# Altium schematic sheet S7CAS-PLEIADES-CARTE-MERE_P01_CAN.SchDoc
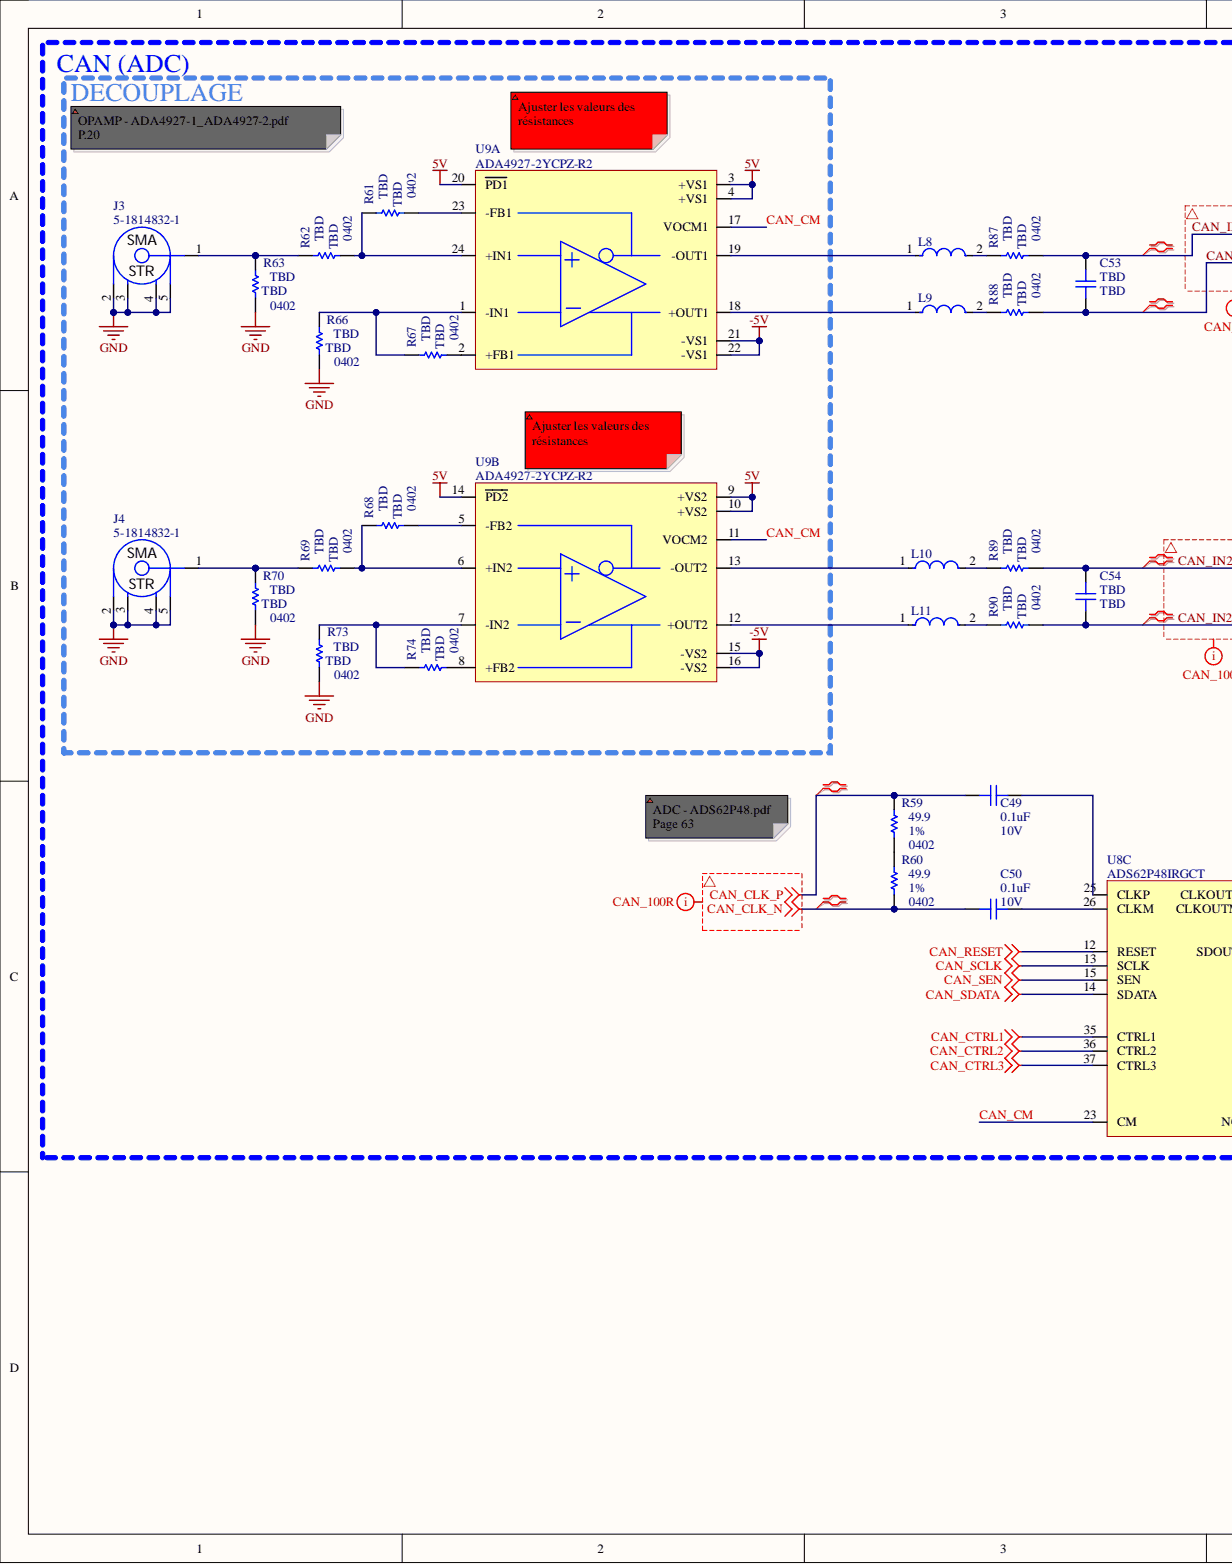
[diagram p0: S7CAS-PLEIADES-CARTE-MERE_P01_CAN.SchDoc, left]
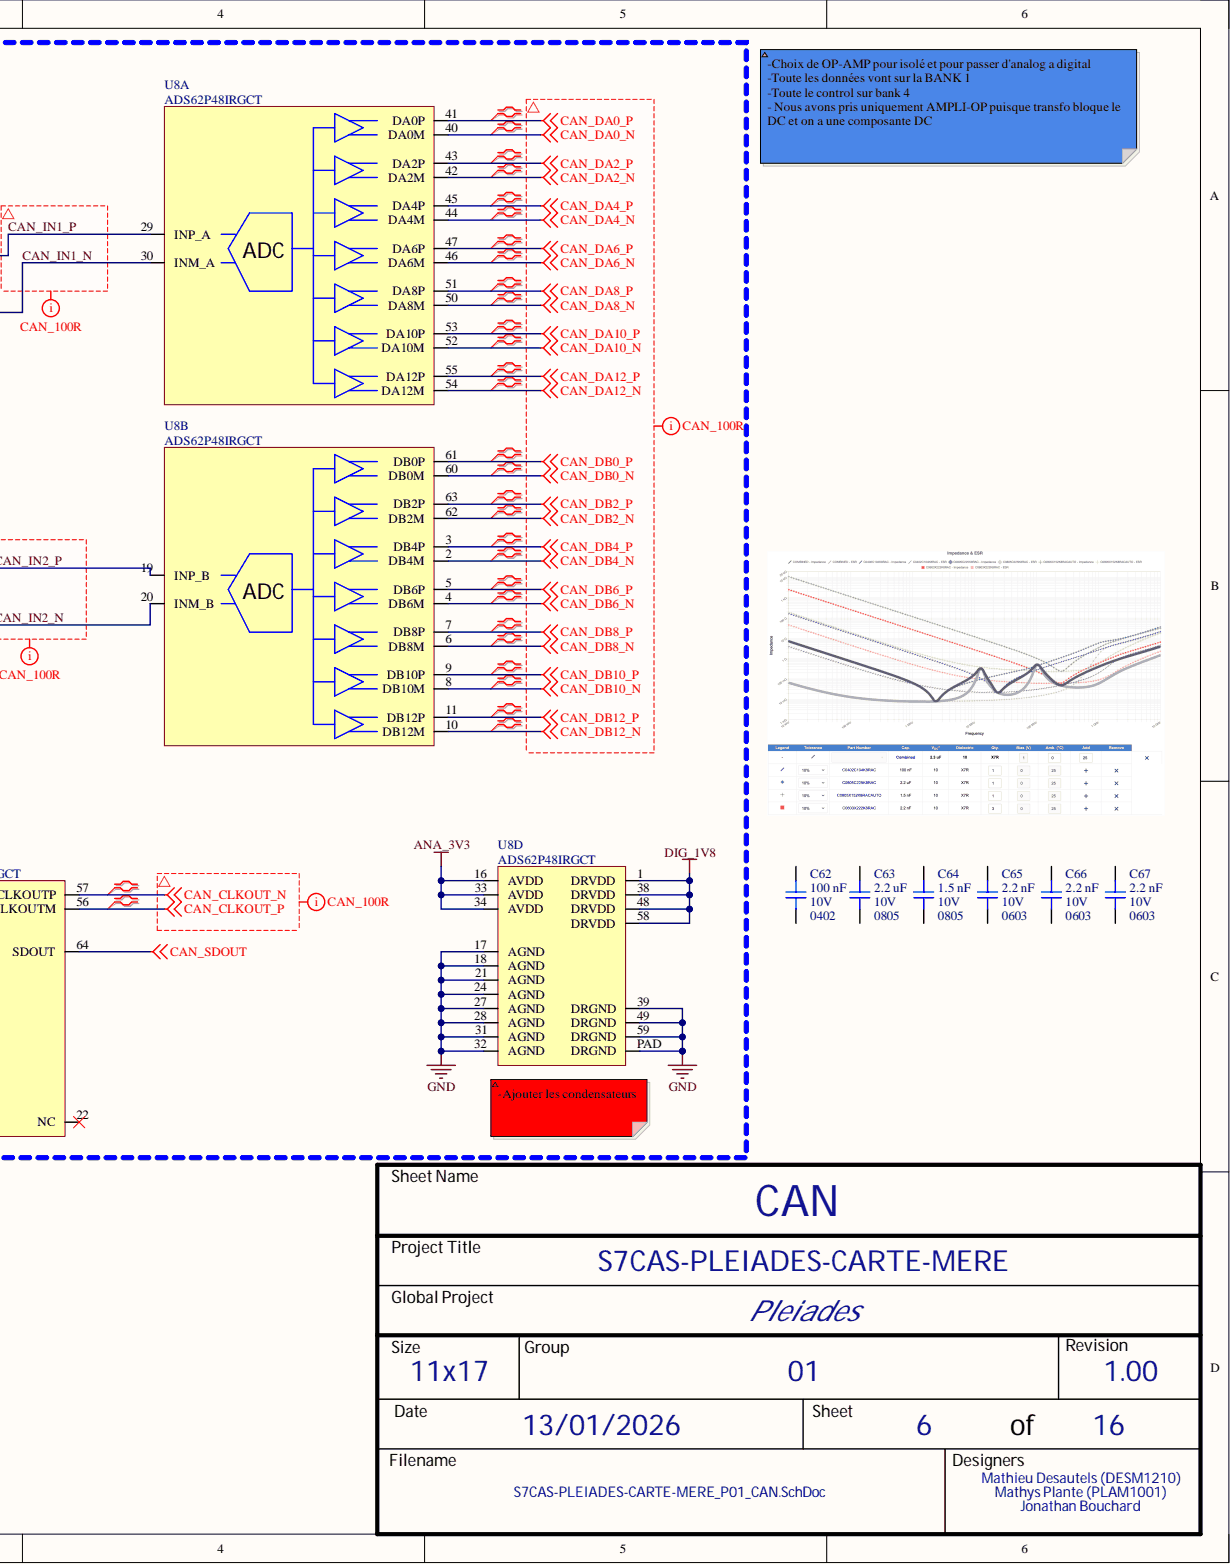
[diagram p1: S7CAS-PLEIADES-CARTE-MERE_P01_CAN.SchDoc, right]

## Parts
C49  value 0.1uF  Voltage: 10V  [CAP_0402]  (hidden comment: CAP - 0402)
C50  value 0.1uF  Voltage: 10V  [CAP_0402]  (hidden comment: CAP - 0402)
C53  value TBD  Mfg: TBD  [CAP_0402]  (hidden comment: CAP - 0402)
C54  value TBD  Mfg: TBD  [CAP_0402]  (hidden comment: CAP - 0402)
C62  value 100 nF  Package: 0402  Voltage: 10V  [CAP_0402]  (hidden comment: CAP - 0402)
C63  value 2.2 uF  Package: 0805  Voltage: 10V  [CAP_0805]  (hidden comment: CAP - 0805)
C64  value 1.5 nF  Package: 0805  Voltage: 10V  [CAP_0805]  (hidden comment: CAP - 0805)
C65  value 2.2 nF  Package: 0603  Voltage: 10V  [CAP_0603]  (hidden comment: CAP - 0603)
C66  value 2.2 nF  Package: 0603  Voltage: 10V  [CAP_0603]  (hidden comment: CAP - 0603)
C67  value 2.2 nF  Package: 0603  Voltage: 10V  [CAP_0603]  (hidden comment: CAP - 0603)
J3  5-1814832-1  [CONN_SMA_5-1814832-1]
J4  5-1814832-1  [CONN_SMA_5-1814832-1]
L8  LQH5BPN1R2NT0L  [FP-LQH5BPB_T0-MFG]  (value not drawn)
L9  LQH5BPN1R2NT0L  [FP-LQH5BPB_T0-MFG]  (value not drawn)
L10  LQH5BPN1R2NT0L  [FP-LQH5BPB_T0-MFG]  (value not drawn)
L11  LQH5BPN1R2NT0L  [FP-LQH5BPB_T0-MFG]  (value not drawn)
R59  value 49.9  Precision: 1%  [RES_0402]  (hidden comment: RES - 0402)
R60  value 49.9  Package: 0402  Precision: 1%  [RES_0402]  (hidden comment: RES - 0402)
R61  value TBD  Mfg: TBD  Package: 0402  [RES_0402]  (hidden comment: RES - 0402)
R62  value TBD  Mfg: TBD  [RES_0402]  (hidden comment: RES - 0402)
R63  value TBD  Mfg: TBD  Package: 0402  [RES_0402]  (hidden comment: RES - 0402)
R66  value TBD  Mfg: TBD  Package: 0402  [RES_0402]  (hidden comment: RES - 0402)
R67  value TBD  Mfg: TBD  [RES_0402]  (hidden comment: RES - 0402)
R68  value TBD  Mfg: TBD  Package: 0402  [RES_0402]  (hidden comment: RES - 0402)
R69  value TBD  Mfg: TBD  [RES_0402]  (hidden comment: RES - 0402)
R70  value TBD  Mfg: TBD  Package: 0402  [RES_0402]  (hidden comment: RES - 0402)
R73  value TBD  Mfg: TBD  Package: 0402  [RES_0402]  (hidden comment: RES - 0402)
R74  value TBD  Mfg: TBD  [RES_0402]  (hidden comment: RES - 0402)
R87  value TBD  Mfg: TBD  Package: 0402  [RES_0402]  (hidden comment: RES - 0402)
R88  value TBD  Mfg: TBD  Package: 0402  [RES_0402]  (hidden comment: RES - 0402)
R89  value TBD  Mfg: TBD  Package: 0402  [RES_0402]  (hidden comment: RES - 0402)
R90  value TBD  Mfg: TBD  Package: 0402  [RES_0402]  (hidden comment: RES - 0402)
U8  ADS62P48IRGCT  [ADC_ADS62P48IRGCT]  (parts A,B,C,D)
U9  ADA4927-2YCPZ-R2  [OPAMP_ADA4927-2YCPZ-R2]  (parts A,B)

## Nets
5V: U9.3(+VS1) U9.4(+VS1) U9.9(+VS2) U9.10(+VS2) U9.14(PD2) U9.20(PD1)
-5V: U9.15(-VS2) U9.16(-VS2) U9.21(-VS1) U9.22(-VS1)
ANA_3V3: U8.16(AVDD) U8.33(AVDD) U8.34(AVDD)
CAN_CLK_N: C50.2 R60.1
CAN_CLK_P: C49.2 R59.2
CAN_CLKOUT_N: U8.57(CLKOUTP)
CAN_CLKOUT_P: U8.56(CLKOUTM)
CAN_CTRL1: U8.35(CTRL1)
CAN_CTRL2: U8.36(CTRL2)
CAN_CTRL3: U8.37(CTRL3)
CAN_DA0_N: U8.40(DA0M)
CAN_DA0_P: U8.41(DA0P)
CAN_DA2_N: U8.42(DA2M)
CAN_DA2_P: U8.43(DA2P)
CAN_DA4_N: U8.44(DA4M)
CAN_DA4_P: U8.45(DA4P)
CAN_DA6_N: U8.46(DA6M)
CAN_DA6_P: U8.47(DA6P)
CAN_DA8_N: U8.50(DA8M)
CAN_DA8_P: U8.51(DA8P)
CAN_DA10_N: U8.52(DA10M)
CAN_DA10_P: U8.53(DA10P)
CAN_DA12_N: U8.54(DA12M)
CAN_DA12_P: U8.55(DA12P)
CAN_DB0_N: U8.60(DB0M)
CAN_DB0_P: U8.61(DB0P)
CAN_DB2_N: U8.62(DB2M)
CAN_DB2_P: U8.63(DB2P)
CAN_DB4_N: U8.2(DB4M)
CAN_DB4_P: U8.3(DB4P)
CAN_DB6_N: U8.4(DB6M)
CAN_DB6_P: U8.5(DB6P)
CAN_DB8_N: U8.6(DB8M)
CAN_DB8_P: U8.7(DB8P)
CAN_DB10_N: U8.8(DB10M)
CAN_DB10_P: U8.9(DB10P)
CAN_DB12_N: U8.10(DB12M)
CAN_DB12_P: U8.11(DB12P)
CAN_RESET: U8.12(RESET)
CAN_SCLK: U8.13(SCLK)
CAN_SDATA: U8.14(SDATA)
CAN_SDOUT: U8.64(SDOUT)
CAN_SEN: U8.15(SEN)
DIG_1V8: U8.1(DRVDD) U8.38(DRVDD) U8.48(DRVDD) U8.58(DRVDD)
GND: J3.2 J3.3 J3.4 J3.5 J4.2 J4.3 J4.4 J4.5 R63.1 R66.1 R70.1 R73.1 U8.17(AGND) U8.18(AGND) U8.21(AGND) U8.24(AGND) U8.27(AGND) U8.28(AGND) U8.31(AGND) U8.32(AGND) U8.39(DRGND) U8.49(DRGND) U8.59(DRGND) U8.PAD(DRGND)
CAN_CM: U8.23(CM) U9.11(VOCM2) U9.17(VOCM1)
CAN_IN1_N: C53.1 R88.1 U8.30(INM_A)
CAN_IN1_P: C53.2 R87.1 U8.29(INP_A)
CAN_IN2_N: C54.1 R90.1 U8.20(INM_B)
CAN_IN2_P: C54.2 R89.1 U8.19(INP_B)
NetC49_1: C49.1 U8.25(CLKP)
NetC50_1: C50.1 U8.26(CLKM)
NetJ3_1: J3.1 R62.2 R63.2
NetJ4_1: J4.1 R69.2 R70.2
NetL8_1: L8.1 U9.19(-OUT1)
NetL8_2: L8.2 R87.2
NetL9_1: L9.1 U9.18(+OUT1)
NetL9_2: L9.2 R88.2
NetL10_1: L10.1 U9.13(-OUT2)
NetL10_2: L10.2 R89.2
NetL11_1: L11.1 U9.12(+OUT2)
NetL11_2: L11.2 R90.2
NetR59_1: R59.1 R60.2
NetR61_1: R61.1 U9.23(-FB1)
NetR61_2: R61.2 R62.1 U9.24(+IN1)
NetR66_2: R66.2 R67.2 U9.1(-IN1)
NetR67_1: R67.1 U9.2(+FB1)
NetR68_1: R68.1 U9.5(-FB2)
NetR68_2: R68.2 R69.1 U9.6(+IN2)
NetR73_2: R73.2 R74.2 U9.7(-IN2)
NetR74_1: R74.1 U9.8(+FB2)

Not connected: C62 1 2; C63 1 2; C64 1 2; C65 1 2; C66 1 2; C67 1 2; U8 22(NC)

## Library data of the parts
C49 C50 = CAP - 0402: 0.1 µF ±10% 10V Ceramic Capacitor X5R 0402 (1005 Metric); Mfg Part Number: CL05A104KP5NNNC; Mfg: Samsung Electro-Mechanics; Component Kind: Standard; Library Name: s7cas_lib2023.intlib; Package: 0402; Part Number #1: CL05A104KP5NNNC; Precision: 10%; CreatedBy: Jonathan Bouchard
C53 C54 = CAP - 0402: TBD - 0402; Component Kind: Standard; Library Name: s7cas_lib2023.intlib; Mfg Part Number: TBD; Package: 0402; Part Number #1: TBD; Precision: TBD; Voltage: TBD; CreatedBy: Jonathan Bouchard
C62 = CAP - 0402: Mfg Part Number: C0402C104K8RAC; Precision: 10%; Component Kind: Standard; Description: CAP - 0402; Library Name: s7cas_lib2023.intlib; CreatedBy: Jonathan Bouchard
C63 = CAP - 0805: Mfg Part Number: C0805C225K8RAC; Precision: 10%; Component Kind: Standard; Description: CAP - 0805; Library Name: s7cas_lib2023.intlib; CreatedBy: Jonathan Bouchard
C64 = CAP - 0805: Mfg Part Number: C0805X152K8RACAUTO; Precision: 10%; Component Kind: Standard; Description: CAP - 0805; Library Name: s7cas_lib2023.intlib; CreatedBy: Jonathan Bouchard
C65-C67 = CAP - 0603: Mfg Part Number: C0603X222K8RAC; Precision: 10%; Component Kind: Standard; Description: CAP - 0603; Library Name: s7cas_lib2023.intlib; CreatedBy: Jonathan Bouchard
J3 J4 = CONN_SMA_5-1814832-1: CONN -  SMA JACK STR 50 OHM - TH; Mfg: TE Connectivity AMP Connectors; Component Kind: Standard; HelpURL: http://www.te.com/commerce/DocumentDelivery/DDEController?Action=srchrtrv&DocNm=1814832&DocType=Customer+Drawing&DocLang=English; Library Name: s7cas_lib2023.intlib; Mfg Part Number: 5-1814832-1; Package: TH-5; Supplier 1: Digikey; Supplier Part Number 1: A97594-ND; Temperature: -65°C ~ 165°C; CreatedBy: Jonathan Bouchard
L8-L11 = CMP-06042-001298-1: Wire Wound Ferrite Inductor for Power Lines 1.2μH ±30% 0.0228Ω 3.1A 2020; DC Resistance (DCR): 19mR; Inductance: 1.2uH; Saturation Current: 5.4A; Self Resonant Frequency: 90MHz; Shielding: Shielded; Test Frequency: 100kHz; Case/Package: 2020; Component Kind: Standard; Core Material: Ferrite; Current Rating: 3.1A; Height: 2mm; Height - Seated (Max): 0.086inch; Inductance Tolerance: 30%; Length: 0.197inch; Library Name: Altium Content Vault; Max DC Current: 3.1A; Max Operating Temperature: 85°C; Min Operating Temperature: -40°C; Mount: Surface Mount; Package Quantity: 500; Packaging: Tape and Reel; REACH SVHC: No SVHC; Resistor Type: Wirewound; Termination: SMD/SMT; Tolerance: 30%; Width: 5mm
R59 R60 = RES - 0402: 49.9 Ohms ±1% 0.1W, 1/10W Chip Resistor 0402 (1005 Metric) Automotive AEC-Q200 Thick Film; Mfg Part Number: CRCW040249R9FKED; Mfg: Vishay Dale; Voltage: 75V; Component Kind: Standard; Library Name: s7cas_lib2023.intlib; Part Number #1: CRCW040249R9FKED; Power: 1/10W; CreatedBy: Jonathan Bouchard
  R59: Package: 0402
R61-R63 R66-R70 R73 R74 R87-R90 = RES - 0402: TBD - 0402; Component Kind: Standard; Library Name: s7cas_lib2023.intlib; Mfg Part Number: TBD; Part Number #1: TBD; Power: TBD; Precision: TBD; Voltage: TBD; CreatedBy: Jonathan Bouchard
  R62 R67 R69 R74: Package: 0402
U8 = ADC_ADS62P48IRGCT: ADC - 14 BIT 210MSPS SUAL - VQFN-64; Mfg: Texas Instruments; Precision: 14 Bit; Voltage: 3.15 V ~ 3.6 V / 1.7 V ~ 1.9 V; Component Kind: Standard; HelpURL: http://www.ti.com/lit/ds/symlink/ads62p48.pdf; Library Name: s7cas_lib2023.intlib; Mfg Part Number: ADS62P48IRGCT; Package: VQFN-64; Supplier 1: Digikey; Supplier Part Number 1: 296-24611-1-ND; Temperature: -40°C ~ 85°C; CreatedBy: Jonathan Bouchard
U9 = OPAMP_ADA4927-2YCPZ-R2: OPAMP - CFA 2.3GHZ - QFN-24; Current: 65mA; Mfg: Analog Devices; Voltage: 4.5 V ~ 11 V, ±2.25 V ~ 5.5 V; Component Kind: Standard; HelpURL: http://www.analog.com/media/en/technical-documentation/data-sheets/ADA4927-1_4927-2.pdf; Library Name: s7cas_lib2023.intlib; Mfg Part Number: ADA4927-2YCPZ-R2; Package: QFN-24; Supplier 1: Digikey; Supplier Part Number 1: ADA4927-2YCPZ-R2CT-ND; Temperature: -40°C ~ 105°C; CreatedBy: Jonathan Bouchard
